# Altium schematic sheet Sensor_Suite.SchDoc
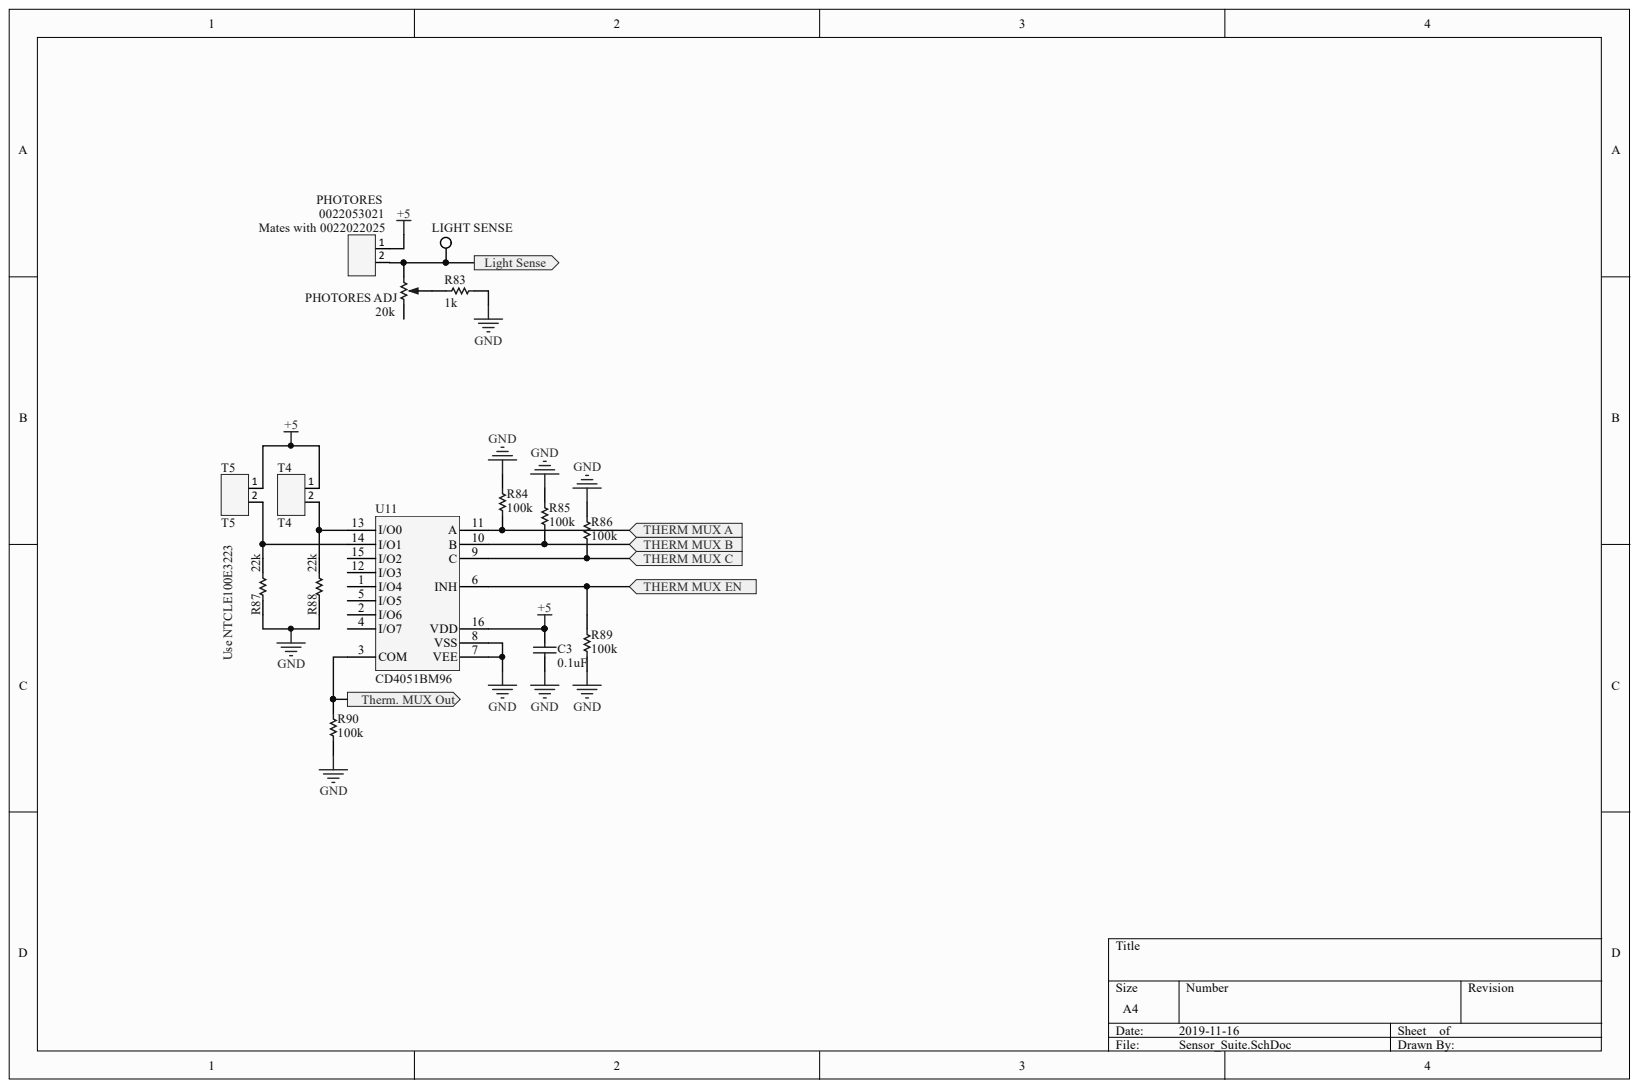
[diagram p0: Sensor_Suite.SchDoc]

## Parts
C3  0.1uF  [PCB-icbybh227nt85afy03bt-1]
LIGHT_SENSE  BYPASS  [PCB-6rf74k5lqbtmzvabbodp-1]  (value not drawn)
PHOTORES  0022053021  [PCB-ovwdwjkavyplx0vnj7zz-1]
PHOTORES_ADJ  20k  [PCB-9c9st4rikhlhcybvfjyu-1]
R83  1k  [PCB-nfpm1qdn7afv6u4jpkts-1]
R84  100k  [PCB-8m9rktjyp1k274dv6t06-1]
R85  100k  [PCB-8m9rktjyp1k274dv6t06-1]
R86  100k  [PCB-8m9rktjyp1k274dv6t06-1]
R87  22k  [PCB-6umf12bttk1btn48hitc-1]
R88  22k  [PCB-6umf12bttk1btn48hitc-1]
R89  100k  [PCB-8m9rktjyp1k274dv6t06-1]
R90  100k  [PCB-8m9rktjyp1k274dv6t06-1]
T4  T4  [PCB-ovwdwjkavyplx0vnj7zz-1]
T5  T5  [PCB-ovwdwjkavyplx0vnj7zz-1]
U11  CD4051BM96  [PCB-pn8iw3pjeggaqok4u95x-1]

## Ports
LIGHT SENSE, THERM MUX A, THERM MUX B, THERM MUX C, THERM MUX EN, THERM. MUX OUT

## Nets
+5: C3.2 PHOTORES.1 T4.1 T5.1 U11.16(VDD)
GND: C3.1 R83.2 R84.1 R85.1 R86.1 R87.1 R88.1 R89.1 R90.1 U11.7(VEE) U11.8(VSS)
THERM MUX A: R84.2 U11.11(A) ; port THERM MUX A
THERM MUX B: R85.2 U11.10(B) ; port THERM MUX B
THERM MUX C: R86.2 U11.9(C) ; port THERM MUX C
THERM MUX EN: R89.2 U11.6(INH) ; port THERM MUX EN
NetLIGHT SENSE_TP: LIGHT_SENSE.TP PHOTORES.2 PHOTORES_ADJ.3 ; port LIGHT SENSE
NetPHOTORES ADJ_2: PHOTORES_ADJ.2 R83.1
NetR87_2: R87.2 T5.2 U11.14(I/O1)
NetR88_2: R88.2 T4.2 U11.13(I/O0)
NetR90_2: R90.2 U11.3(COM) ; port THERM. MUX OUT

Not connected: PHOTORES_ADJ 1; U11 1(I/O4) 2(I/O6) 4(I/O7) 5(I/O5) 12(I/O3) 15(I/O2)

## Library data of the parts
C3 = CMP-64ddadbcac66bb1a-2: Ceramic Capacitor, 0.1uf, 50v, X7r, 10%, 0603, Full Reel; Component Kind: Standard; Library Name: CommunityVault
LIGHT_SENSE = 5002: KEYSTONE   5002   Series:-; Lead-Free Status: Lead Free; Color: White; Component Kind: Standard; Contact Material: Phosphor Bronze; Contact Plating: Tin; Library Name: Octopart library; Manufacturer: Keystone; Manufacturer Part Number: 5002; OctopartID: f34a3a40c2652c8d; Octopart Url: https://octopart.com/5002-keystone-5193; Packaging: Bag; REACH SVHC Compliance: No SVHC; RoHS: Compliant; Size-Diameter: 2.54 mm; Size-Height: 7.62 mm; Size-Inner Diameter: 1.27 mm; Size-Length: 7.62 mm
PHOTORES T4 T5 = CMP-u3nfpohxq28cpayqzppy-2: CONN HEADER R/A 2POS 2.54MM; Component Kind: Standard; CustomComponentID: u3nfpohxq28cpayqzppy; Library Name: FavoritesLibrary
PHOTORES_ADJ = 3296Y-1-203LF: Pot; Power Rating: 500 mW; Resistance: 20.0 kΩ; Resistance Tolerance: ±10%; Temperature Coefficient: -100 ppm/°C to 100 ppm/°C; Voltage Rating (DC): 300 V; Color: Blue; Component Kind: Standard; Library Name: Octopart library; Manufacturer Part Number: 3296Y-1-203LF; Mounting Style: Through Hole; OctopartID: dacc13c74ec9050b; Octopart URL: https://octopart.com/3296y-1-203lf-bourns-110947; Operating Temperature: -55.0 °C to 150 °C; Packaging: Tube; REACH SVHC Compliance: No SVHC; Size-Length: 9.53 mm; Size-Width: 4.83 mm; Weight: 851 mg
R83 = CMP-e9fc21d24e86363b-2: Res Thick Film 0603 1K Ohm 5% 0.25W(1/4W) ±200ppm/C Pad SMD Automotive T/R; Manufacturer Part Number: ESR03EZPJ102; Case/Package (SI): 1608; Lead-Free Status: Lead Free; Power Rating: 250 mW; Resistance: 1.00 k?; Temperature Coefficient: -200 ppm/°C to 200 ppm/°C; Voltage Rating (DC): 150 V; Case/Package: 1608, 0603; Component Kind: Standard; Library Name: FavoritesLibrary; Lifecycle Status: Not Listed by Manufacturer; OctopartID: e9fc21d24e86363b; Octopart URL: https://octopart.com/esr03ezpj102-rohm-19169820; Packaging: Cut Tape (CT); Resistance Tolerance: ±5%; RoHS: Compliant
R84-R86 R89 R90 = CRGCQ0603J100K: Res, Auto, Thick Film, 100K, 5%, 0603; Component Kind: Standard; Library Name: Octopart library; Lifecycle Status: Not Listed by Manufacturer
R87 R88 = CMP-1853446-7: Res Thick Film 0603 22K Ohm 1% 0.1W(1/10W) ±100ppm/C Pad SMD T/R; Manufacturer Part Number: RC0603FR-0722KL; Case/Package (SI): 1608; Lead-Free Status: Lead Free; Power Rating: 100 mW; Resistance: 22.0 k?; Temperature Coefficient: -100 ppm/°C to 100 ppm/°C; Voltage Rating (DC): 75.0 V, 50.0 V; Case/Package: 0603; Component Kind: Standard; Library Name: FavoritesLibrary; Mounting Style: Surface Mount; Number of Pins: 2; OctopartID: 2162ea18e018fea5; Octopart URL: https://octopart.com/rc0603fr-0722kl-yageo-40952860; Operating Temperature: 155 °C (max); Packaging: Tape & Reel; REACH SVHC Compliance: No SVHC; Resistance Tolerance: ±1%; RoHS: Compliant; Size-Height: 450 µm; Size-Length: 1.60 mm; Size-Width: 800 µm
U11 = CMP-3ce3f5ee2d39e324-4: Multiplexer IC Operating temperature: -55...+125 °C Series: CD4051 Package: SOIC-16; Bandwidth: 20.0 MHz; Lead-Free Status: Lead Free; Number of Bits: 8; Polarity: Non-Inverting; Propagation Delay Max (tpd): 20.0 ns; Quiescent Current: 80.0 nA; Supply Current: 100 µA; Supply Voltage (DC): 3.00 V (min), 20.0 V (max); Voltage Nodes: 10.0 V, 5.00 V, 15.0 V; Case/Package: PDIP, SOIC; Component Kind: Standard; Library Name: FavoritesLibrary; Lifecycle Status: Active; Manufacturer Part Number: CD4051BM96; Mounting Style: Surface Mount; Number of Channels: 8; Number of Pins: 16; OctopartID: 3ce3f5ee2d39e324; Octopart URL: https://octopart.com/cd4051bm96-texas+instruments-472252; Operating Temperature: 125 °C (max); Packaging: Cut Tape (CT), Reel, Tape & Reel (TR); REACH SVHC Compliance: No SVHC; RoHS: Compliant
